# Altium schematic sheet Modulo baterias.SchDoc
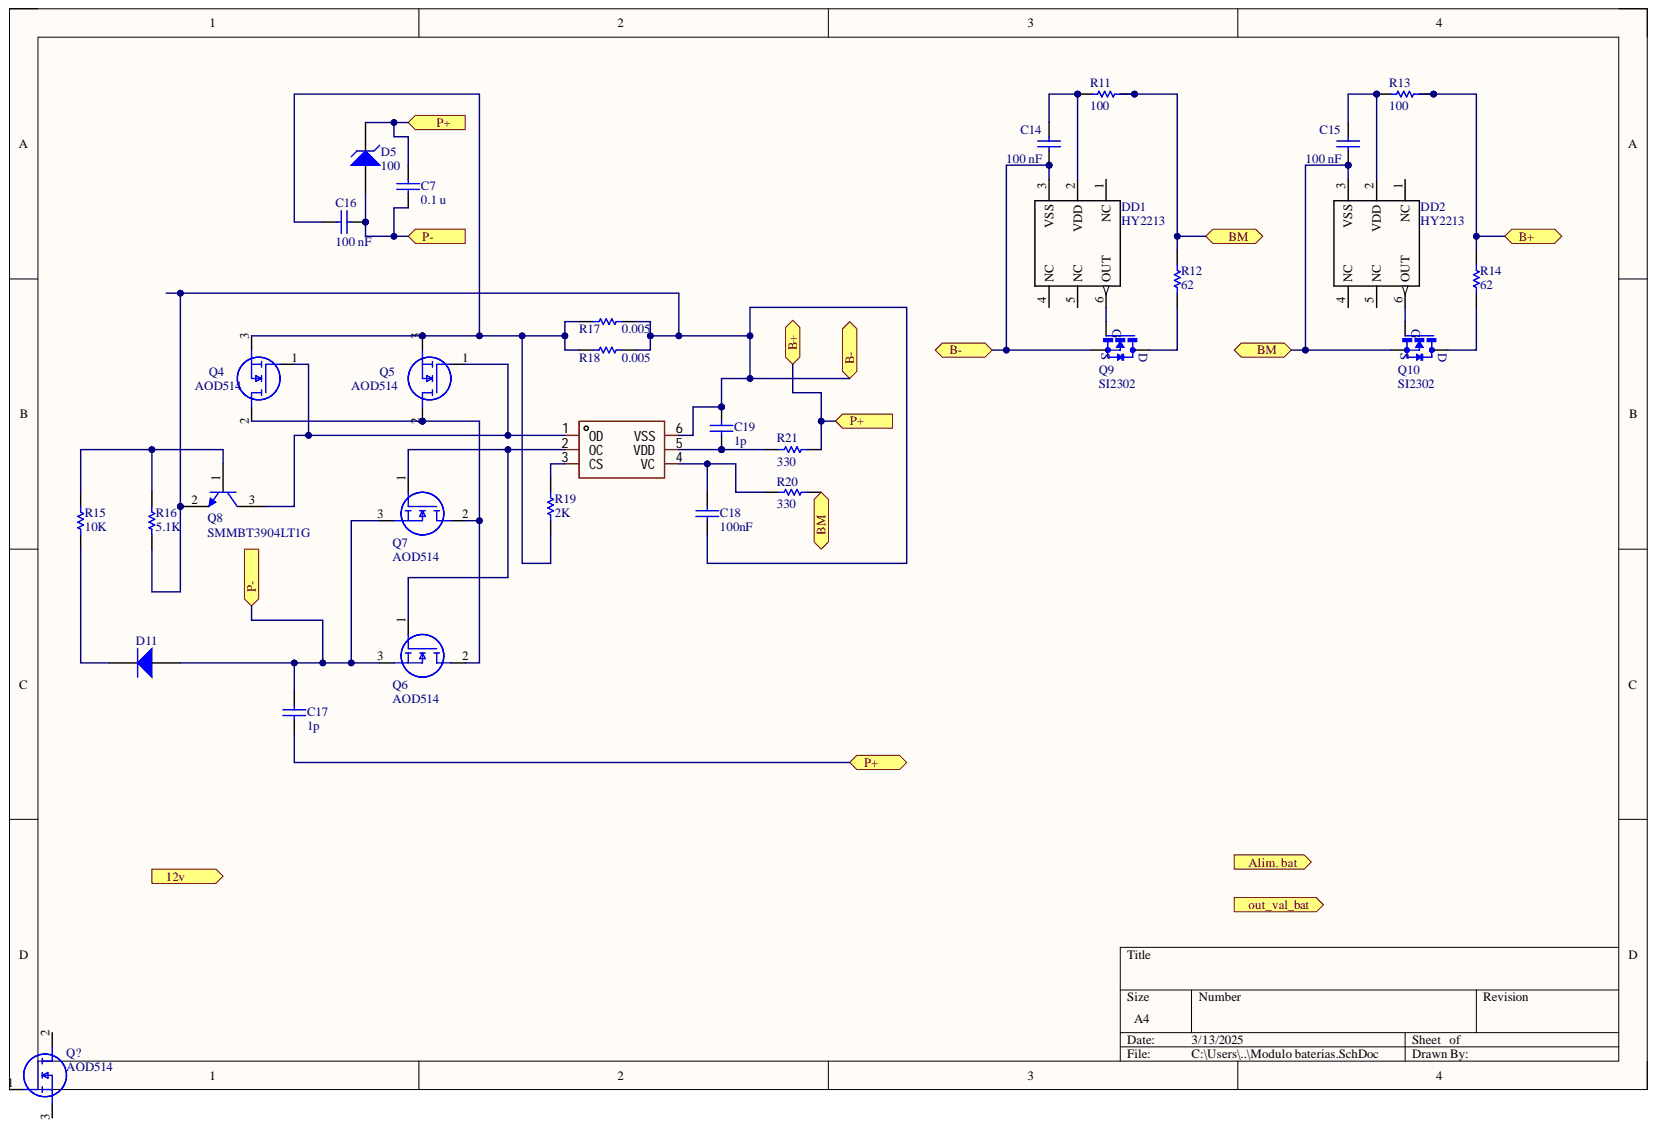
[diagram p0: Modulo baterias.SchDoc]

## Parts
C7  value 0.1 u  (hidden comment: Capacitor)
C14  value 100 nF  (hidden comment: Capacitor)
C15  value 100 nF  (hidden comment: Capacitor)
C16  value 100 nF  (hidden comment: Capacitor)
C17  value 1p  (hidden comment: Capacitor)
C18  value 100nF  (hidden comment: Capacitor)
C19  value 1p  (hidden comment: Capacitor)
D5  Zener Diode  (value not drawn)
D11  Diode  (value not drawn)
DD1  HY2213  [SOT23-6]
DD2  HY2213  [SOT23-6]
Q4  AOD514  [AOD514]
Q5  AOD514  [AOD514]
Q6  AOD514  [AOD514]
Q7  AOD514  [AOD514]
Q8  SMMBT3904LT1G  [ONSC-SOT-23-3-318-08_V]
Q9  SI2302  [SOT23]
Q10  SI2302  [SOT23]
R11  value 100  (hidden comment: Resistor)
R12  value 62  (hidden comment: Resistor)
R13  value 100  (hidden comment: Resistor)
R14  value 62  (hidden comment: Resistor)
R15  value 10K  (hidden comment: Resistor)
R16  value 5.1K  (hidden comment: Resistor)
R17  value 0.005  (hidden comment: Resistor)
R18  value 0.005  (hidden comment: Resistor)
R19  value 2K  (hidden comment: Resistor)
R20  value 330  (hidden comment: Resistor)
R21  value 330  (hidden comment: Resistor)
U?  HY2120-CB  [SOT-23-6_L2.9-W1.6-P0.95-LS2.8-BR]  (value not drawn)

## Ports
12v, Alim. bat, B+, B-, BM, out_val_bat, P+, P-

## Nets
BM: C15.1 DD2.3(VSS) Q10.2 R11.2 R12.2 R20.1 ; port BM
NetC7_1: C7.1 C16.1 C17.2 D5.1 D11.1 Q6.3 Q7.3
NetC7_2: C7.2 C17.1 D5.2 R13.2 R14.2 R21.1
NetC14_1: C14.1 C18.1 C19.2 DD1.3(VSS) Q8.2 Q9.2 R16.1 R17.1 R18.1 U?.6(VSS)
NetC14_2: C14.2 DD1.2(VDD) R11.1
NetC15_2: C15.2 DD2.2(VDD) R13.1
NetC16_2: C16.2 Q4.3 Q5.3 R17.2 R18.2 R19.1
NetC18_2: C18.2 R20.2 U?.4(VC)
NetC19_1: C19.1 R21.2 U?.5(VDD)
NetD11_2: D11.2 R15.1
NetDD1_6: DD1.6(OUT) Q9.1
NetDD2_6: DD2.6(OUT) Q10.1
NetQ4_1: Q4.1 Q5.1 Q8.3 U?.1(OD)
NetQ4_2: Q4.2 Q5.2 Q6.2 Q7.2
NetQ6_1: Q6.1 Q7.1 U?.2(OC)
NetQ8_1: Q8.1 R15.2 R16.2
NetQ9_3: Q9.3 R12.1
NetQ10_3: Q10.3 R14.1
NetR19_2: R19.2 U?.3(CS)
N00001: ; port Alim. bat
N00002: ; port out_val_bat
N00003: ; port 12v

Not connected: DD1 1(NC) 4(NC) 5(NC); DD2 1(NC) 4(NC) 5(NC)

## Library data of the parts
C7 C14-C19 = Capacitor: SimulationGenericComponent: True; Component Kind: Standard; Description: Capacitor; Library Name: Simulation Generic Components.IntLib; Simulation: C_Capacitor_Default
D5 = Zener Diode: AF: 1; BV: 100; BV_MAX: 1e99; CJO: 0; EG: 1.11; FC: 0.5; IBV: 0.001; IS: 1e-14; MJSW: 0.33; NTUN: 30; SimulationGenericComponent: True; TNOM: 27; XTI: 3; CJP: 0; Component Kind: Standard; CTA: 0; CTP: 0; Description: Zener Diode; FCS: 0.5; FV_MAX: 1e99; IKF: 0; IKR: 0; ISR: 1e-14; JSW: 0; JTUN: 0; JTUNSW: 0; KEG: 1; KF: 0; LEVEL: 1; Library Name: Simulation Generic Components.IntLib; N: 1; NBV: 1; NR: 1; NS: 1; PHP: 1; RS: 0; Simulation: diode; TCV: 0; TLEV: 0; TLEVC: 0; TM1: 0; TM2: 0; TPB: 0; TPHP: 0; TRS: 0; TRS2: 0; TT: 0; TTT1: 0; TTT2: 0; VJ: 1; XTITUN: 3
D11 = Diode: AF: 1; BV: 0; BV_MAX: 1e99; EG: 1.11; FC: 0.5; IBV: 0.001; IS: 1e-14; MJSW: 0.33; NTUN: 30; SimulationGenericComponent: True; TNOM: 27; XTI: 3; CJO: 0; CJP: 0; Component Kind: Standard; CTA: 0; CTP: 0; Description: Diode; FCS: 0.5; FV_MAX: 1e99; IKF: 0; IKR: 0; ISR: 1e-14; JSW: 0; JTUN: 0; JTUNSW: 0; KEG: 1; KF: 0; LEVEL: 1; Library Name: Simulation Generic Components.IntLib; N: 1; NBV: 1; NR: 1; NS: 1; PHP: 1; RS: 0; Simulation: diode; TCV: 0; TLEV: 0; TLEVC: 0; TM1: 0; TM2: 0; TPB: 0; TPHP: 0; TRS: 0; TRS2: 0; TT: 0; TTT1: 0; TTT2: 0; VJ: 1; XTITUN: 3
DD1 DD2 = HY2213: 1 Cell Li-ion/Polymer Battery Charge Balance IC; Component Kind: Standard; Library Name: HY2213-BB3A.SchLib
Q4-Q7 = AOD514: MOSFET (N-Channel); Manufacturer_Name: Alpha & Omega Semiconductors; Arrow Part Number: AOD514; Arrow Price/Stock: https://www.arrow.com/en/products/aod514/alpha-and-omega-semiconductor?region=nac; Component Kind: Standard; Datasheet Link: https://aosmd.com/res/data_sheets/AOD514.pdf; Height: 2.6mm; Library Name: SamacSys.SchLib; Manufacturer_Part_Number: AOD514
Q8 = CMP-1048-00625-1: General Purpose Transistor, NPN Silicon, 3-Pin SOT-23, Pb-Free, Tape and Reel; Manufacturer: On Semiconductor; fT Min (MHz): 300; IC Continuous (A): 0.2; Component Kind: Standard; ComponentLink1Description: Manufacturer URL; ComponentLink1URL: http://www.onsemi.com/; ComponentLink2Description: Datasheet; ComponentLink2URL: http://www.onsemi.cn/pub_link/Collateral/MMBT3904LT1-D.PDF; ComponentLink3Description: Package Specification; ComponentLink3URL: http://www.onsemi.cn/pub_link/Collateral/318-08.PDF; DatasheetVersion: Rev. 12; Library Name: Components - 13.03.25 - 17.40.SchLib; Mounting Technology: Surface Mount; PackageDescription: 3-Pin Small Outline Transistor, Body 2.92 x 1.3 mm, Pitch 0.95 mm; PackageReference: SOT-23-3-318-08; PackageVersion: Rev. AP, 11/2009; Packing: Tape and Reel; PartNumber: SMMBT3904LT1G; Polarity: NPN; RoHS: TRUE
Q9 Q10 = SI2302: N-Channel 20 V 2.6A (Ta) 710mW (Ta) Surface Mount SOT-23-3 (TO-236); MF: Vishay Siliconix; Availability: In Stock; Check_prices: https://www.snapeda.com/parts/SI2302/Vishay+Siliconix/view-part/?ref=eda; Component Kind: Standard; Library Name: SI2302.SchLib; MP: SI2302; Package: SOT-23-3 Vishay Siliconix; Price: None; Purchase-URL: https://pricing.snapeda.com/search/part/SI2302/?ref=eda; SnapEDA_Link: https://www.snapeda.com/parts/SI2302/Vishay+Siliconix/view-part/?ref=snap
R11-R21 = Resistor: SimulationGenericComponent: True; TC1: 0; Component Kind: Standard; Description: Resistor; Library Name: Simulation Generic Components.IntLib; Simulation: R_Resistor_Default; TC2: 0
U? = HY2120-CB: Manufacturer: HYCON(宏康); Add into BOM: yes; Symbol: HY2120CB; Component Kind: Standard; Convert to PCB: yes; Datasheet: https://atta.szlcsc.com/upload/public/pdf/source/20180104/C168785_15150385461851227384.pdf; Device: HY2120-CB; JLCPCB Part Class: Extended Part; LCSC Part Name: HY2120-CB; Manufacturer Part: HY2120-CB; PCB3D: SOT-23-6_L2.9-W1.6-H1.5-LS2.8-P0.95; Supplier: LCSC; Supplier Footprint: SOT-23-6; Supplier Part: C116509
